# Altium schematic sheet Main.SchDoc
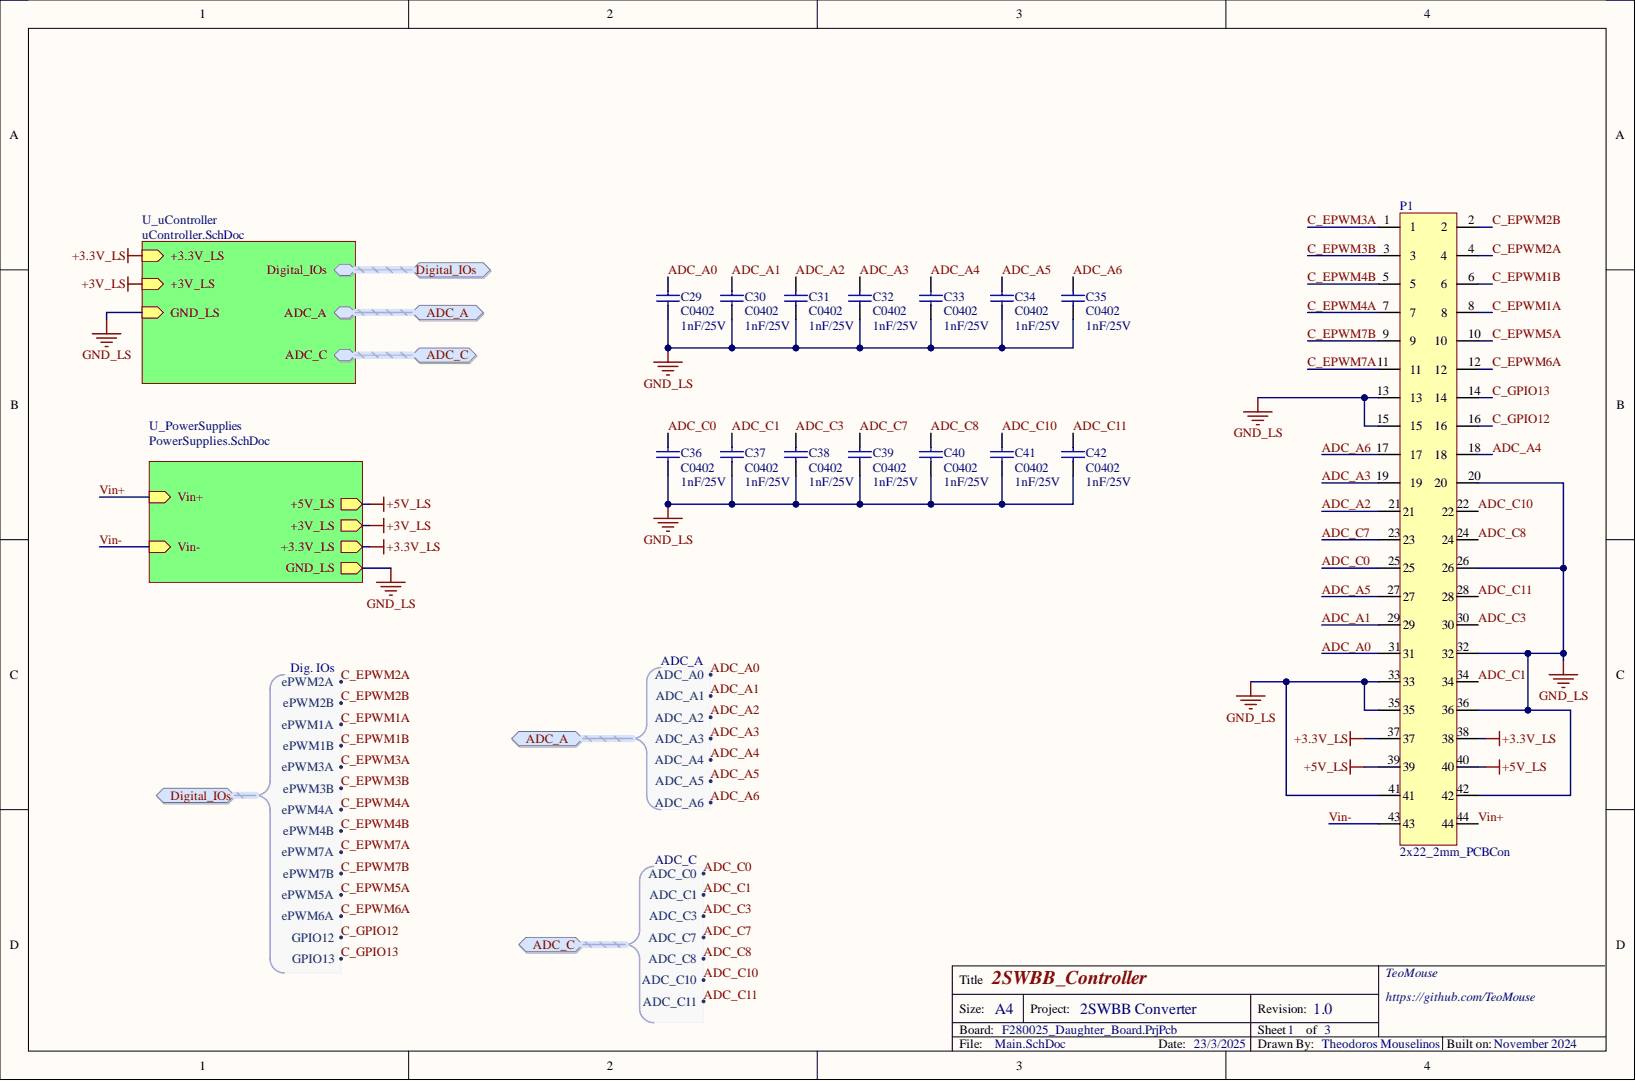
[diagram p0: Main.SchDoc]

## Parts
C29  C0402  value 1nF/25V  [Capacitor 0402]
C30  C0402  value 1nF/25V  [Capacitor 0402]
C31  C0402  value 1nF/25V  [Capacitor 0402]
C32  C0402  value 1nF/25V  [Capacitor 0402]
C33  C0402  value 1nF/25V  [Capacitor 0402]
C34  C0402  value 1nF/25V  [Capacitor 0402]
C35  C0402  value 1nF/25V  [Capacitor 0402]
C36  C0402  value 1nF/25V  [Capacitor 0402]
C37  C0402  value 1nF/25V  [Capacitor 0402]
C38  C0402  value 1nF/25V  [Capacitor 0402]
C39  C0402  value 1nF/25V  [Capacitor 0402]
C40  C0402  value 1nF/25V  [Capacitor 0402]
C41  C0402  value 1nF/25V  [Capacitor 0402]
C42  C0402  value 1nF/25V  [Capacitor 0402]
P1  2x22_2mm_PCBCon  [Con_2mm_PCB_2x22]

## Ports
ADC_A, ADC_A.ADC_A0, ADC_A.ADC_A1, ADC_A.ADC_A2, ADC_A.ADC_A3, ADC_A.ADC_A4, ADC_A.ADC_A5, ADC_A.ADC_A6, ADC_C, ADC_C.ADC_C0, ADC_C.ADC_C1, ADC_C.ADC_C3, ADC_C.ADC_C7, ADC_C.ADC_C8, ADC_C.ADC_C10, ADC_C.ADC_C11, Digital_IOs, Digital_IOs.ePWM1A, Digital_IOs.ePWM1B, Digital_IOs.ePWM2A, Digital_IOs.ePWM2B, Digital_IOs.ePWM3A, Digital_IOs.ePWM3B, Digital_IOs.ePWM4A, Digital_IOs.ePWM4B, Digital_IOs.ePWM5A, Digital_IOs.ePWM6A, Digital_IOs.ePWM7A, Digital_IOs.ePWM7B, Digital_IOs.GPIO12, Digital_IOs.GPIO13

## Nets
+3.3V_LS: P1.37 P1.38
+5V_LS: P1.39 P1.40
GND_LS: C29.1 C30.1 C31.1 C32.1 C33.1 C34.1 C35.1 C36.1 C37.1 C38.1 C39.1 C40.1 C41.1 C42.1 P1.13 P1.15 P1.20 P1.26 P1.32 P1.33 P1.35 P1.36 P1.41 P1.42
ADC_A0: C29.2 P1.31 ; port ADC_A
ADC_A1: C30.2 P1.29 ; port ADC_A
ADC_A2: C31.2 P1.21 ; port ADC_A
ADC_A3: C32.2 P1.19 ; port ADC_A
ADC_A4: C33.2 P1.18 ; port ADC_A
ADC_A5: C34.2 P1.27 ; port ADC_A
ADC_A6: C35.2 P1.17 ; port ADC_A
ADC_C0: C36.2 P1.25 ; port ADC_C
ADC_C1: C37.2 P1.34 ; port ADC_C
ADC_C3: C38.2 P1.30 ; port ADC_C
ADC_C7: C39.2 P1.23 ; port ADC_C
ADC_C8: C40.2 P1.24 ; port ADC_C
ADC_C10: C41.2 P1.22 ; port ADC_C
ADC_C11: C42.2 P1.28 ; port ADC_C
C_EPWM1A: P1.8 ; port Digital_IOs
C_EPWM1B: P1.6 ; port Digital_IOs
C_EPWM2A: P1.4 ; port Digital_IOs
C_EPWM2B: P1.2 ; port Digital_IOs
C_EPWM3A: P1.1 ; port Digital_IOs
C_EPWM3B: P1.3 ; port Digital_IOs
C_EPWM4A: P1.7 ; port Digital_IOs
C_EPWM4B: P1.5 ; port Digital_IOs
C_EPWM5A: P1.10 ; port Digital_IOs
C_EPWM6A: P1.12 ; port Digital_IOs
C_EPWM7A: P1.11 ; port Digital_IOs
C_EPWM7B: P1.9 ; port Digital_IOs
C_GPIO12: P1.16 ; port Digital_IOs
C_GPIO13: P1.14 ; port Digital_IOs
Vin+: P1.44
Vin-: P1.43
+3V_LS:

## Library data of the parts
C29-C42 = C0402: Capacitor; Component Kind: Standard; LatestRevisionDate: 17-Jul-2002; LatestRevisionNote: Re-released for DXP Platform.; Library Name: CommonSchLib.SchLib; PackageDescription: Radial Cap, Thru-Hole; 2 Leads; 0.3 in Pin Spacing; PackageReference: RAD-0.3; Published: 8-Jun-2000; Publisher: Altium Limited
P1 = 2x22_2mm_PCBCon: Component Kind: Standard; Library Name: CommonSchLib.SchLib; Supplier 1: Mouser; Supplier Part Number 1: 200-FTS12201FD
